# Altium schematic sheet J-Link Cortex with VCOM Adapter.SchDoc
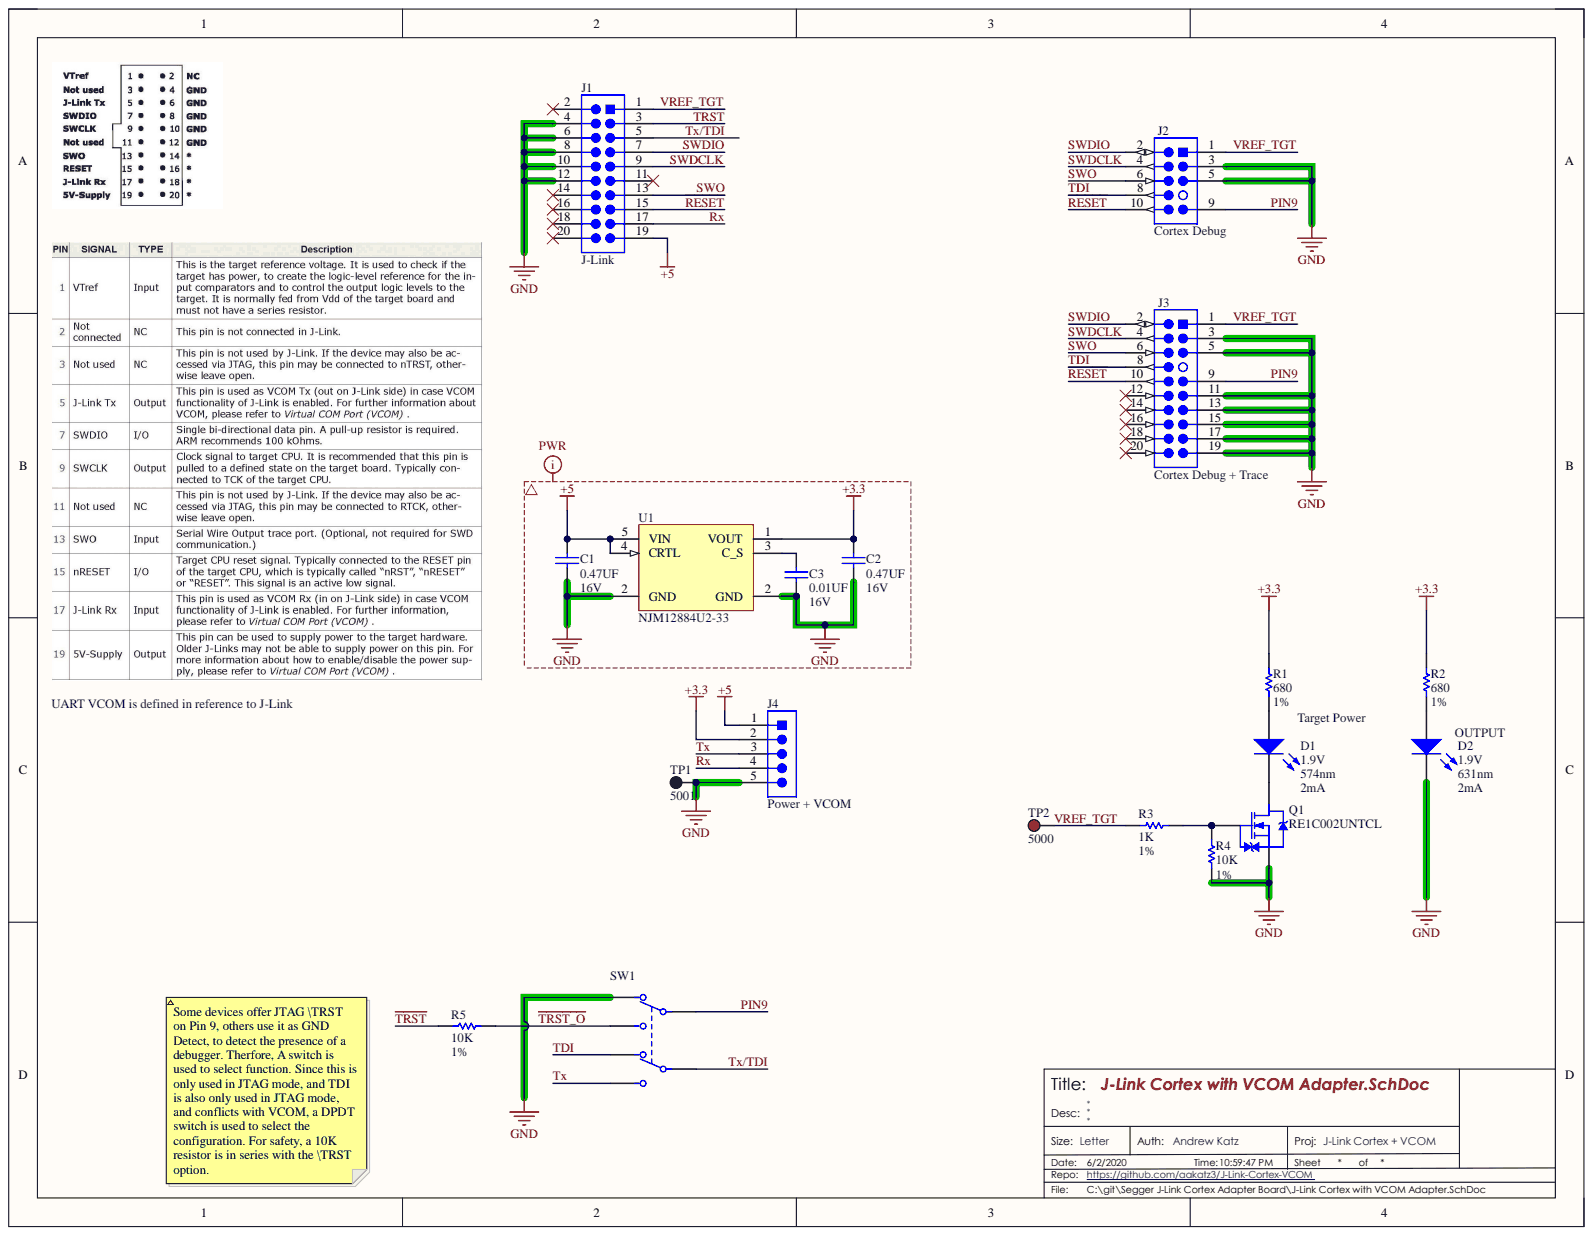
[diagram p0: J-Link Cortex with VCOM Adapter.SchDoc]

## Parts
C1  value 0.47UF  Val: 0.47UF  Vol: 16V  [0603_CAP]  (hidden comment: CAP 0603 0.47UF 16V 10% X7R)
C2  value 0.47UF  Val: 0.47UF  Vol: 16V  [0603_CAP]  (hidden comment: CAP 0603 0.47UF 16V 10% X7R)
C3  value 0.01UF  Val: 0.01UF  Vol: 16V  [0603_CAP]  (hidden comment: CAP 0603 0.01UF 16V 10% X7R)
D1  Test Current: 2mA  Voltage Rating: 1.9V  Wavelength: 574nm  [SM0805GCL]  (hidden comment: Green LED)
D2  Test Current: 2mA  Voltage Rating: 1.9V  Wavelength: 631nm  [SM0805HCL]  (hidden comment: Red LED)
J1  20 contact Female header, RA  [SFH11-PBPC-D10-RA-BK]  (value not drawn)
J2  Cortex Debug, 0.050" 10 Pin  [FTSH-105-01-F-DV-007-K PLUGOUT]  (value not drawn)
J3  Cortex Debug+ETM Connector, 0.050" 20 Pin  [FTSH-110-01-F-DV-007-K plugout]  (value not drawn)
J4  5 contacts Male header  [70543-0109 - slim]  (value not drawn)
Q1  N-Channel MOSFET  [SC89]  (value not drawn)
R1  value 680  Tol: 1%  [0603_RES]  (hidden comment: RES 0603 680 1%)
R2  value 680  Tol: 1%  [0603_RES]  (hidden comment: RES 0603 680 1%)
R3  value 1K  Tol: 1%  Val: 1K  [0603_RES]  (hidden comment: RES 0603 1K 1%)
R4  value 10K  Tol: 1%  Val: 10K  [0603_RES]  (hidden comment: RES 0603 10K 1%)
R5  value 10K  Tol: 1%  Val: 10K  [0603_RES]  (hidden comment: RES 0603 10K 1%)
SW1  SWITCH SLIDE DPDT 300MA 6V  [JS202011CQN]  (value not drawn)
TP1  5001  [KSTN-5001]
TP2  5000  [KSTN-5000]
U1  3.3V LDO Soft start reverse current reverse voltage  [SOT89-5_NJR]  (value not drawn)

## Nets
+3.3: C2.2 J4.2 R1.2 R2.2 U1.1(VOUT)
+5: C1.2 J1.19 J4.1 U1.4(CRTL) U1.5(VIN)
GND: C1.1 C2.1 C3.1 D2.K J1.4 J1.6 J1.8 J1.10 J1.12 J2.3 J2.5 J3.3 J3.5 J3.11 J3.13 J3.15 J3.17 J3.19 J4.5 Q1.2 R4.1 SW1.1 TP1.1 U1.2(GND)
~{RESET}: J1.15 J2.10 J3.10
~{TRST}: J1.3 R5.1
~{TRST_O}: R5.2 SW1.3
PIN9: J2.9 J3.9 SW1.2
Rx: J1.17 J4.4
SWDCLK: J1.9 J2.4 J3.4
SWDIO: J1.7 J2.2 J3.2
SWO: J1.13 J2.6 J3.6
TDI: J2.8 J3.8 SW1.4
Tx: J4.3 SW1.6
Tx/TDI: J1.5 SW1.5
VREF_TGT: J1.1 J2.1 J3.1 R3.1 TP2.1
NetC3_2: C3.2 U1.3(C_S)
NetD1_A: D1.A R1.1
NetD1_K: D1.K Q1.3
NetD2_A: D2.A R2.1
NetQ1_1: Q1.1 R3.2 R4.2

Not connected: J1 2 11 14 16 18 20; J3 12 14 16 18 20

## Library data of the parts
C1 C2 = CAP 0603 0.47UF 16V 10% X7R: Capacitor Surface Mount 0603 Footprint 0.47UF 16V 10% Tolerance Ceramic X7R; Manufacturer: Various; Description in Visual: Cap SMT 0603 0.47UF 16V 10%  Cer X7R; PCB Package: 0603; Component Kind: Standard; ComponentLink1Description: Manufacturer Website; ComponentLink2Description: Component Datasheet; ComponentLink3Description: Octopart Link; Database Table Name: Capacitors; Library Name: Passive Components.DbLib; Manufacturer P/N: Various; P/N: CAP 0603 0.47UF 16V 10% X7R; Simulation: C; Tolerance: 10%; Type: Ceramic X7R; Voltage: 16V
C3 = CAP 0603 0.01UF 16V 10% X7R: Capacitor Surface Mount 0603 Footprint 0.01UF 16V 10% Tolerance Ceramic X7R; Manufacturer: Various; Description in Visual: Cap SMT 0603 0.01UF 16V 10%  Cer X7R; PCB Package: 0603; Component Kind: Standard; ComponentLink1Description: Manufacturer Website; ComponentLink2Description: Component Datasheet; ComponentLink3Description: Octopart Link; Database Table Name: Capacitors; Library Name: Passive Components.DbLib; Manufacturer P/N: Various; P/N: CAP 0603 0.01UF 16V 10% X7R; Simulation: C; Tolerance: 10%; Type: Ceramic X7R; Voltage: 16V
D1 = SM0805GCL: Green 574nm LED Indication - Discrete 1.9V 0805 (2012 Metric); Manufacturer: Bivar; Configuration: Single; Database Table Name: LEDs; Lens Style: Square; Lens Type: Clear; Millicandela Rating: 3.6mcd; Package Class: Surface Mount; PCB Package: 0805; Viewing Angle: 130°; Color: Green; Component Kind: Standard; ComponentLink1Description: Manufacturer Website; ComponentLink1URL: #https://www.bivar.com/#; ComponentLink2Description: Component Datasheet; ComponentLink2URL: https://www.bivar.com/parts_content/Datasheets/SM0805HCL.pdf; ComponentLink3Description: Octopart Link; ComponentLink3URL: #https://octopart.com/sm0805gcl-bivar-22059573?r=sp#; Description in Visual: Green 574nm LED Indication - Discrete 1.9V 0805 (2012 Metric); Library Name: Discrete Semiconductors.DbLib; Manufacturer P/N: SM0805GCL; P/N: SM0805GCL
D2 = SM0805HCL: Red 631nm LED Indication - Discrete 1.9V 0805 (2012 Metric); Manufacturer: Bivar; Configuration: Single; Database Table Name: LEDs; Lens Style: Square; Lens Type: Clear; Millicandela Rating: 11.5mcd; Package Class: Surface Mount; PCB Package: 0805; Viewing Angle: 130°; Color: Red; Component Kind: Standard; ComponentLink1Description: Manufacturer Website; ComponentLink1URL: #https://www.bivar.com/#; ComponentLink2Description: Component Datasheet; ComponentLink2URL: https://www.bivar.com/parts_content/Datasheets/SM0805HCL.pdf; ComponentLink3Description: Octopart Link; ComponentLink3URL: #https://octopart.com/sm0805hcl-bivar-2191581?r=sp#; Description in Visual: Red 631nm LED Indication - Discrete 1.9V 0805 (2012 Metric); Library Name: Discrete Semiconductors.DbLib; Manufacturer P/N: SM0805HCL; P/N: SM0805HCL
J1 = SFH11-PBPC-D10-RA-BK: 20 Position Header Connector  Through Hole, Right Angle; Manufacturer: Sullins; Database Table Name: Headers; Description in Visual: Con Hdr Female 20 contacts horizontal 2X10 keyed; Number of Contacts: 20; PCB Package: 2x10 THT; Pitch: 0.100"; Component Kind: Standard; ComponentLink1Description: Manufacturer Website; ComponentLink1URL: https://www.sullinscorp.com/; ComponentLink2Description: Component Datasheet; ComponentLink2URL: https://datasheet.ciiva.com/754/sfh11-pxxc-dxx-ra-xx11161-c-754566.pdf?src-supplier=Digi-Key; Library Name: Connectors.DbLib; Manufacturer P/N: SFH11-PBPC-D10-RA-BK; Number of Rows: 2; Orientation: horizontal; P/N: SFH11-PBPC-D10-RA-BK; Supplier 1: Digi-Key; Supplier Part Number 1: S9205-ND
J2 = ARM Cortex Debug: 10 Pin Shrouded Header, 0.050", Key Pin 7; Manufacturer: Samtech; Manufacturer P/N: Various; Database Table Name: Debug Connectors; Gender: Male; Number of Contacts: 10; Component Kind: Standard; ComponentLink1Description: Pinout Reference; ComponentLink1URL: http://infocenter.arm.com/help/topic/com.arm.doc.faqs/attached/13634/cortex_debug_connectors.pdf; ComponentLink2Description: Datasheet for Connector; ComponentLink2URL: http://suddendocs.samtec.com/catalog_english/ftsh_smt.pdf; Contact Finish: Gold; Description in Visual: 10 Pin Shrouded Header, 0.050", Key Pin 7; Library Name: Connectors.DbLib; Number of Rows: 2; Orientation: Vertical; P/N: ARM Cortex Debug; Pitch: 0.050"; Supplier 1: Digi-Key; Supplier 2: Digi-Key; Supplier 3: Digi-Key; Supplier Part Number 1: SAM15640-ND; Supplier Part Number 2: SAM13926CT-ND; Supplier Part Number 3: SAM11250-ND
J3 = Cortex Debug+ETM: 20 Pin Shrouded Header, 0.050", Key Pin 7; Manufacturer: Samtech; Manufacturer P/N: Various; Database Table Name: Debug Connectors; Gender: Male; Number of Contacts: 20; Component Kind: Standard; ComponentLink1Description: Pinout Reference; ComponentLink1URL: http://infocenter.arm.com/help/topic/com.arm.doc.faqs/attached/13634/cortex_debug_connectors.pdf; ComponentLink2Description: Datasheet for Connector; ComponentLink2URL: http://suddendocs.samtec.com/catalog_english/ftsh_smt.pdf; Contact Finish: Gold; Description in Visual: 20 Pin Shrouded Header, 0.050", Key Pin 7; Library Name: Connectors.DbLib; Number of Rows: 2; Orientation: Vertical; P/N: Cortex Debug+ETM; Pitch: 0.050"; Supplier 1: Digi-Key; Supplier 2: Avnet; Supplier 3: Digi-Key; Supplier 4: Avnet; Supplier Part Number 1: SAM15422CT-ND; Supplier Part Number 2: FTSH-110-01-F-DV-007-K-TR; Supplier Part Number 3: SAM11264-ND; Supplier Part Number 4: FTSH-110-01-F-DV-007-K-A
J4 = 70543-0109: Connector Male Header 1X5 vertical 5contacts 0.100in pitch 0.76um Gold finish shrouded with latch; Manufacturer: Molex; Database Table Name: Headers; Description in Visual: Con Hdr Male 5contacts vertical 1X5; Number of Contacts: 5; PCB Package: NON-STD TH; Component Kind: Standard; ComponentLink1Description: Manufacturer Website; ComponentLink1URL: http://www.molex.com; ComponentLink2Description: Component Datasheet; ComponentLink2URL: http://www.molex.com/pdm_docs/sd/705430106_sd.pdf; ComponentLink3Description: Octopart Link; ComponentLink3URL: http://octopart.com/partsearch/#search/requestData&q=70543-0109; ComponentLink4Description: Product Page; ComponentLink4URL: http://www.molex.com/molex/products/datasheet.jsp?part=active/0705430109_PCB_HEADERS.xml; Contact Finish: 0.76um Gold; Gender: Male; Library Name: Connectors.DbLib; Manufacturer P/N: 70543-0109; Number of Rows: 1.00; Options: shrouded with latch; Orientation: vertical; P/N: 70543-0109; Pitch: 0.100in; Supplier 1: Digi-Key; Supplier Part Number 1: WM4125-ND
Q1 = RE1C002UNTCL: N-Channel 20V 200mA (Ta) 150mW (Ta) Surface Mount EMT3F (SOT-416FL); Manufacturer: Rohm Semiconductor; Database Table Name: N-Channel MOSFETs; ID (Amps): 200; RDSon (mOhms): 800; Rth(JC) (K/W): 833; Vdss (V): 20; Component Kind: Standard; ComponentLink1Description: Manufacturer Website; ComponentLink1URL: #http://www.rohm.com#; ComponentLink2Description: Component Datasheet; ComponentLink2URL: https://d1d2qsbl8m0m72.cloudfront.net/en/products/databook/datasheet/discrete/transistor/mosfet/re1c002untcl-e.pdf; ComponentLink3Description: Octopart Link; ComponentLink3URL: #https://octopart.com/re1c002untcl-rohm-30008399?r=sp#; ComponentLink4Description: SPICE Model; ComponentLink4URL: http://rohmfs.rohm.com/en/products/library/spice/discrete/transistor/mosfet/re1c002un.lib; Description in Visual: N-Channel 20V 200mA (Ta) 150mW (Ta) Surface Mount EMT3F (SOT-416FL); Library Name: Discrete Semiconductors.DbLib; Manufacturer P/N: RE1C002UNTCL; P/N: RE1C002UNTCL; Package Class: Surface Mount; PCB Package: EMT3F
R1 R2 = RES 0603 680 1%: Resistor Surface Mount, 680 Ohms, 0603 Footprint, 1% Tolerance 0.1W; Manufacturer: Various; Description in Visual: Res SMT 680 0603  1%  0.1W; PCB Package: 0603; Component Kind: Standard; Database Table Name: Resistors; Library Name: Passive Components.DbLib; Manufacturer P/N: Various; P/N: RES 0603 680 1%; Power_Rating: 0.1W; Simulation: Model Name; Supplier 1: Digi-Key; Supplier 2: Digi-Key; Supplier 3: Digi-Key; Supplier 4: Digi-Key; Supplier Part Number 1: 541-680HCT-ND; Supplier Part Number 2: 2019-RK73H1JTTD6800FCT-ND; Supplier Part Number 3: P680HCT-ND; Supplier Part Number 4: YAG3356CT-ND; Tolerance: 1%; Val: 680
R3 = RES 0603 1K 1%: Resistor Surface Mount, 1K Ohms, 0603 Footprint, 1% Tolerance 0.1W; Manufacturer: Various; Description in Visual: Res SMT 1K 0603  1%  0.1W; PCB Package: 0603; Component Kind: Standard; Database Table Name: Resistors; Library Name: Passive Components.DbLib; Manufacturer P/N: Various; P/N: RES 0603 1K 1%; Power_Rating: 0.1W; Simulation: Model Name; Supplier 1: Digi-Key; Supplier 2: Digi-Key; Supplier 3: RSComponents; Supplier 4: Digi-Key; Supplier Part Number 1: 2019-RK73H1JTTD1001FCT-ND; Supplier Part Number 2: 541-1166-1-ND; Supplier Part Number 3: 1051155; Supplier Part Number 4: 311-1.00KHRCT-ND; Tolerance: 1%
R4 R5 = RES 0603 10K 1%: Resistor Surface Mount, 10K Ohms, 0603 Footprint, 1% Tolerance 0.1W; Manufacturer: Various; Description in Visual: Res SMT 10K 0603  1%  0.1W; PCB Package: 0603; Component Kind: Standard; Database Table Name: Resistors; Library Name: Passive Components.DbLib; Manufacturer P/N: Various; P/N: RES 0603 10K 1%; Power_Rating: 0.1W; Simulation: Model Name; Supplier 1: Digi-Key; Supplier 2: Digi-Key; Supplier 3: Newark; Supplier 4: Digi-Key; Supplier Part Number 1: P10.0KHCT-ND; Supplier Part Number 2: 541-10.0KHCT-ND; Supplier Part Number 3: 68R0128; Supplier Part Number 4: A106048CT-ND; Tolerance: 1%
SW1 = JS202011CQN: Slide Switch DPDT Through Hole; Manufacturer: C&K; Actuator Height: 2.0mm; Database Table Name: Switches; Electrical Life: 5000 Cycles; Number of Switches: 1; Rated Voltage (V): 6VDC; Switch Function: On-On; Component Kind: Standard; Library Name: Electromechanical.DbLib; Manufacturer Part Number: JS202011CQN; Mounting Style: Through Hole; P/N: JS202011CQN; Rated Current (A): 300mA; Supplier 1: Digi-Key; Supplier Part Number 1: 401-2001-ND; Temperature Range: -40°C ~ 85°C; Throw Configuration: DPDT; Type: Slide
TP1 = 5001: Test Point, Black, 1-Pin THD, RoHS, Bulk; Brand: KeystoneElectronics; Mounting Hole Size: 1mm; Outside Diameter: 2.54mm; Plating: Silver; Color: Black; Component Kind: Standard; Contact Material: PhosphorBronze; Datasheet URL: https://ciiva.com/datasheet-click-2917627?src=ciiva&sig=bd195616; Datasheet Version: Rev. G, 12/2008; Length: 7.62mm; Library Name: Keystone 500x Series.IntLib; Manufacturer: KeystoneElectronics; Manufacturer URL: http://www.keyelco.com/; Material: Nylon; Packaging: Bulk; Product: TestPoints; Product Category: CircuitBoardHardware-PCB; RoHS: True; Supplier 1: Digi-Key; Supplier Part Number 1: 36-5001-ND; Type: Miniature
TP2 = 5000: Test Point, Red, 1-Pin THD, RoHS, Bulk; Brand: KeystoneElectronics; Mounting Hole Size: 1mm; Outside Diameter: 2.54mm; Plating: Silver; Color: Red; Component Kind: Standard; Contact Material: PhosphorBronze; Datasheet URL: https://ciiva.com/datasheet-click-2917627?src=ciiva&sig=bd195616; Datasheet Version: Rev. G, 12/2008; Length: 7.62mm; Library Name: Keystone 500x Series.IntLib; Manufacturer: KeystoneElectronics; Manufacturer URL: http://www.keyelco.com/; Material: Nylon; Packaging: Bulk; Product: TestPoints; Product Category: CircuitBoardHardware-PCB; RoHS: True; Supplier 1: Digi-Key; Supplier Part Number 1: 36-5000-ND; Type: Miniature
U1 = NJM12884U2-33: Linear Voltage Regulator IC  1 Output  500mA SOT-89-5; Manufacturer: NJR Corporation/NJRC; Manufacturer P/N: NJM12884U2-33-TE1; Database Table Name: Linear Regulators; Initial Error: ±1%; Layout Considerations: Schottky barrier diode is not needed; CIN ≥ 0.33μF; COUT ≥ 0.47μF; PCB Package: SOT89-5-2; Quiescent Current (mA): 0.280; Vin Max (Volts): 6.5; Vin Min (Volts): 3.48; Component Kind: Standard; ComponentLink1Description: Manufacturer's Website; ComponentLink2Description: Component Datasheet; ComponentLink2URL: https://www.njr.com/semicon/PDF/NJM12884_E.pdf; ComponentLink3Description: Octopart Link; ComponentLink3URL: #https://octopart.com/njm12884u2-33-te1-new+japan+radio-92406224?r=sp#; Description in Visual: Linear Voltage Regulator IC  1 Output  500mA SOT-89-5; Features: Control, Soft-start, Discharge, Thermal Shutdown, Over-current, Reverse current; Library Name: Integrated Circuits.DbLib; Output Voltage (Volts): 3.3V; P/N: NJM12884U2-33; Supplier 1: Digi-Key; Supplier Part Number 1: 190-NJM12884U2-33-TE1CT-ND; Temperature Range: -40°C - 125°C; Type: LDO
